# Altium schematic sheet RF.SchDoc
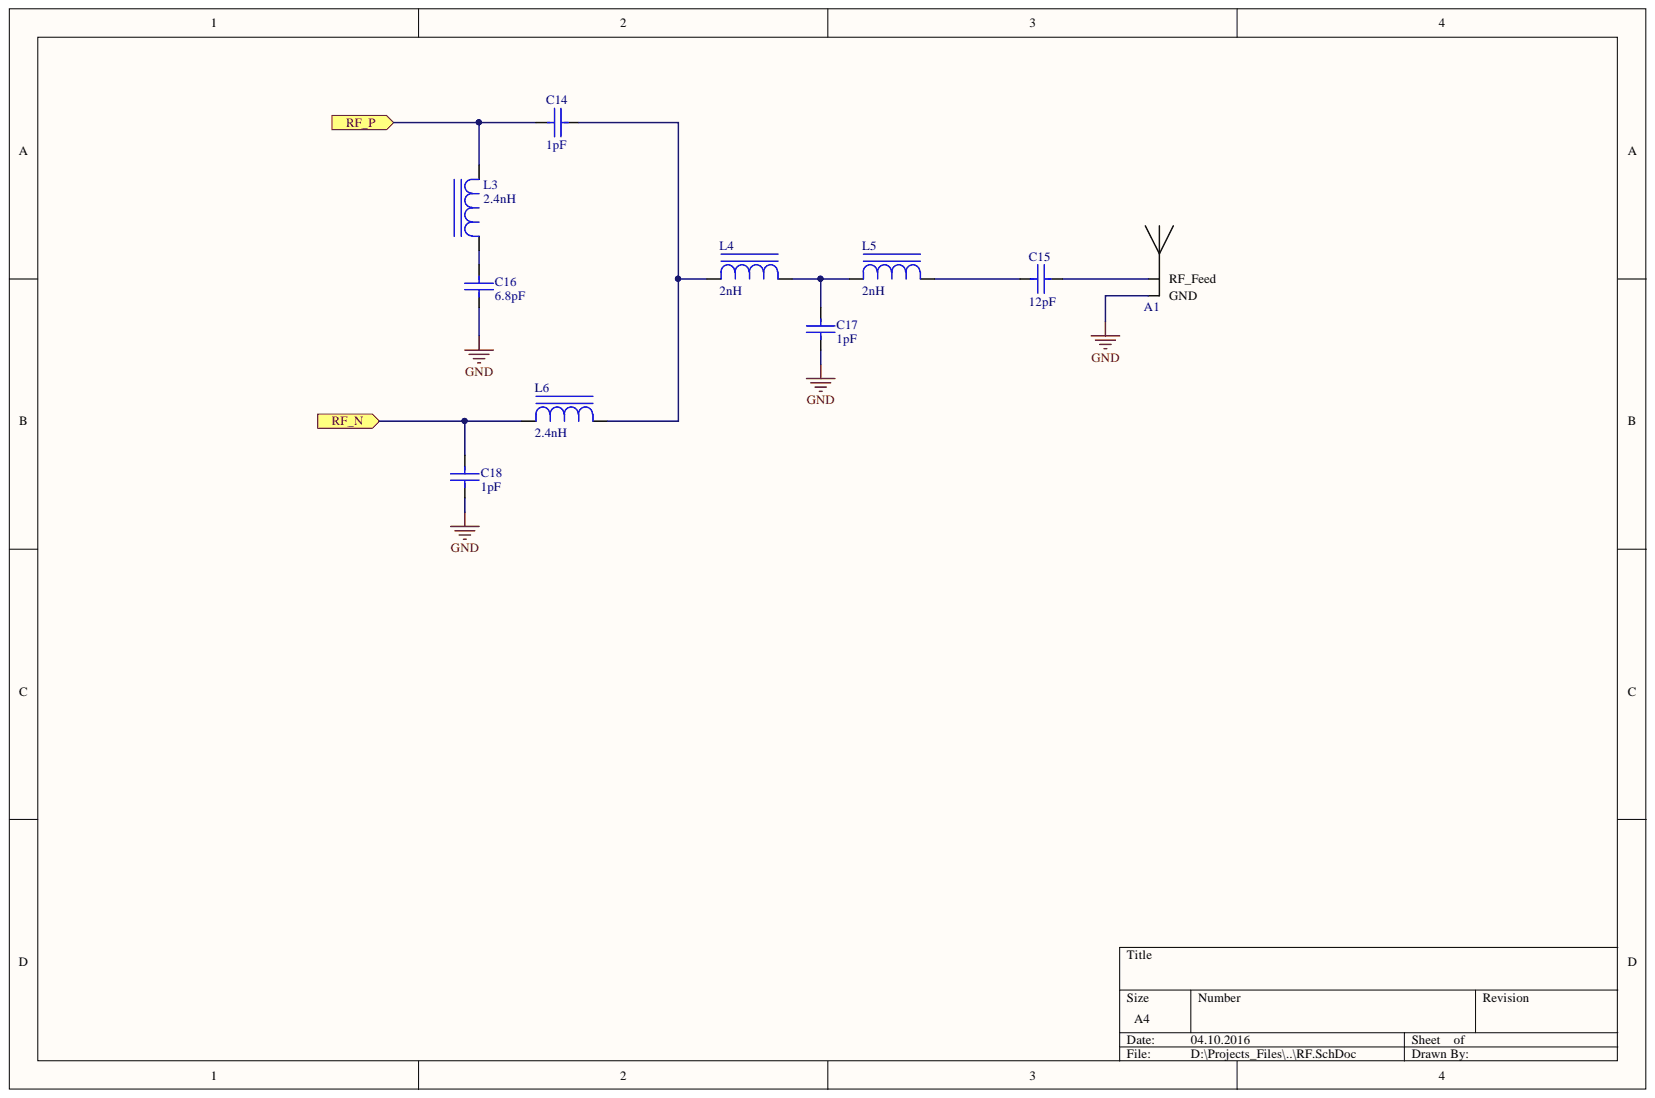
[diagram p0: RF.SchDoc]

## Parts
A1  Antenna Wi-Fi  [Antenna_WiFi]  (value not drawn)
C14  1pF  [CC0402]
C15  12pF  [CC0402]
C16  6.8pF  [CC0402]
C17  1pF  [CC0402]
C18  1pF  [CC0402]
L3  2.4nH  [L0402]
L4  2nH  [L0402]
L5  2nH  [L0402]
L6  2.4nH  [L0402]

## Ports
RF_N, RF_P

## Nets
GND: A1.2 C16.1 C17.1 C18.1
RF_N: C18.2 L6.1 ; port RF_N
RF_P: C14.2 L3.2 ; port RF_P
NetA1_1: A1.1 C15.2
NetC14_1: C14.1 L4.1 L6.2
NetC15_1: C15.1 L5.2
NetC16_2: C16.2 L3.1
NetC17_2: C17.2 L4.2 L5.1

## Library data of the parts
A1 = Antenna Wi-Fi: 2.43GHz; Component Kind: Standard; Library Name: gprs_modem_2.SchLib
C14-C18 = CC0402: Component Kind: Standard; Library Name: gprs_modem_2.SchLib
  C14 C17 C18: Value: 1pF
  C15: Value: 12pF
  C16: Value: 6.8pF
L3-L6 = L0402: Component Kind: Standard; Description: L0402; Library Name: gprs_modem_2.SchLib
  L3 L6: Value: 2.4nH
  L4 L5: Value: 2nH
